# Altium schematic sheet MCU.SchDoc(MCU)
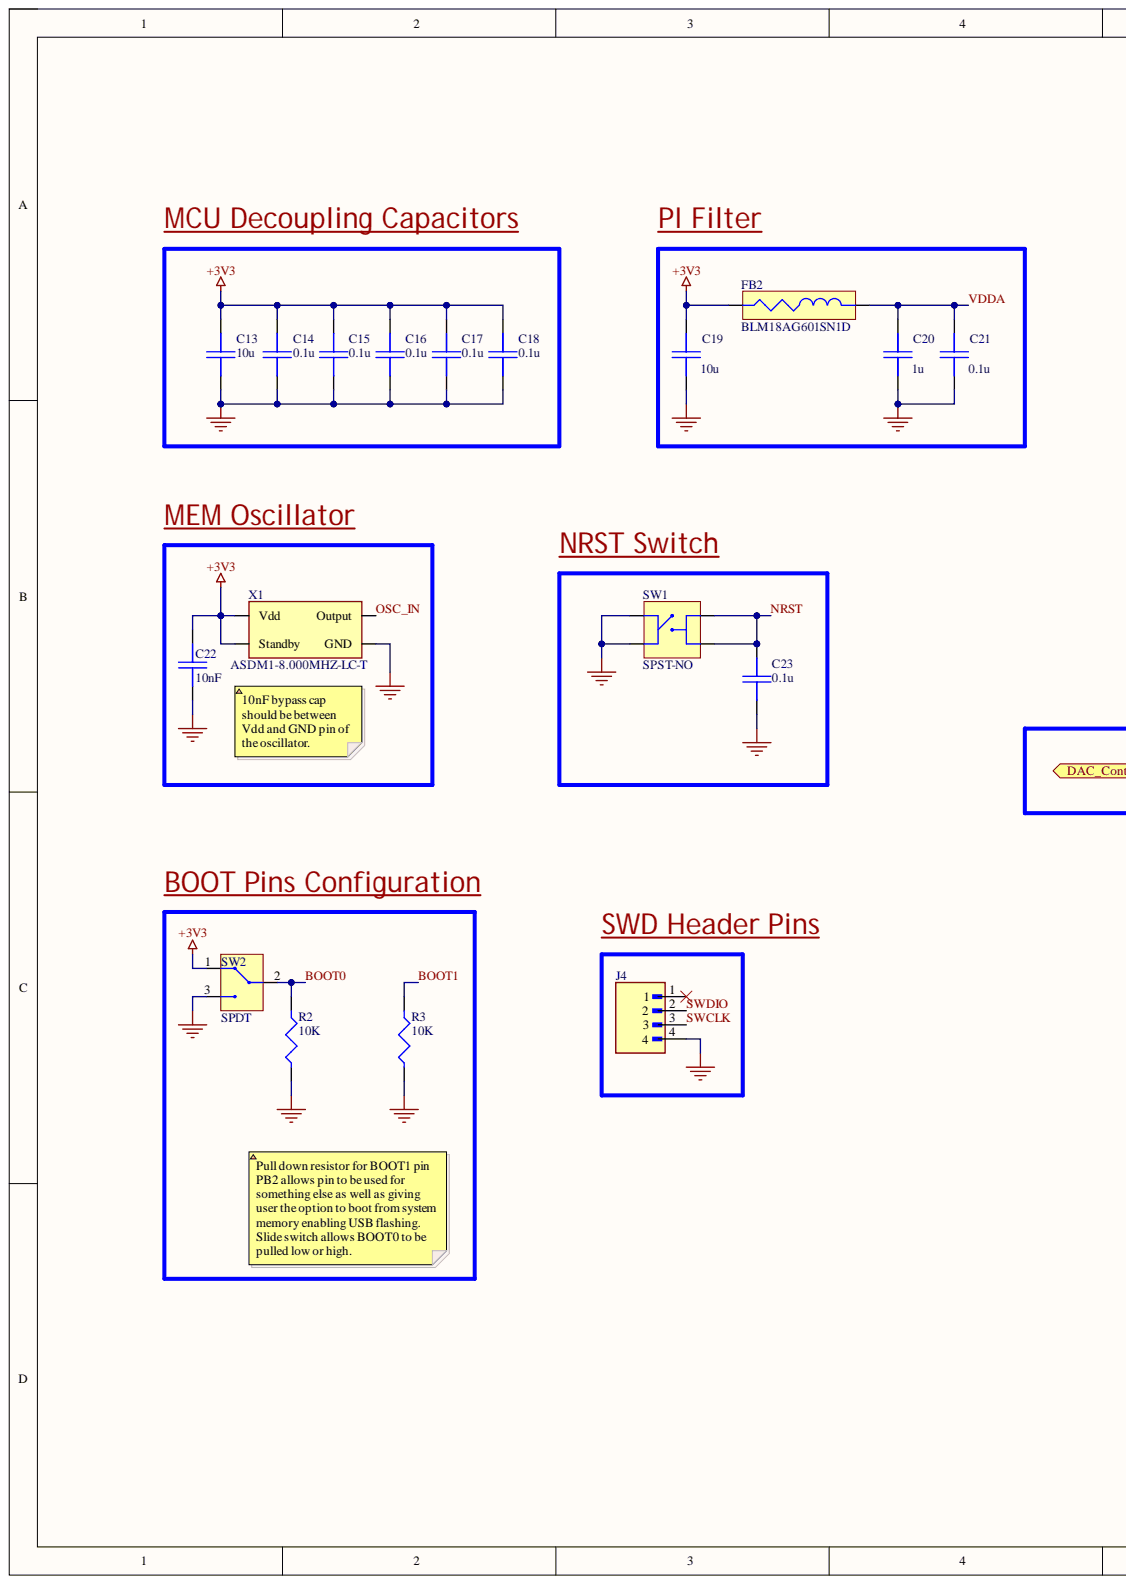
[diagram p0: MCU.SchDoc, left]
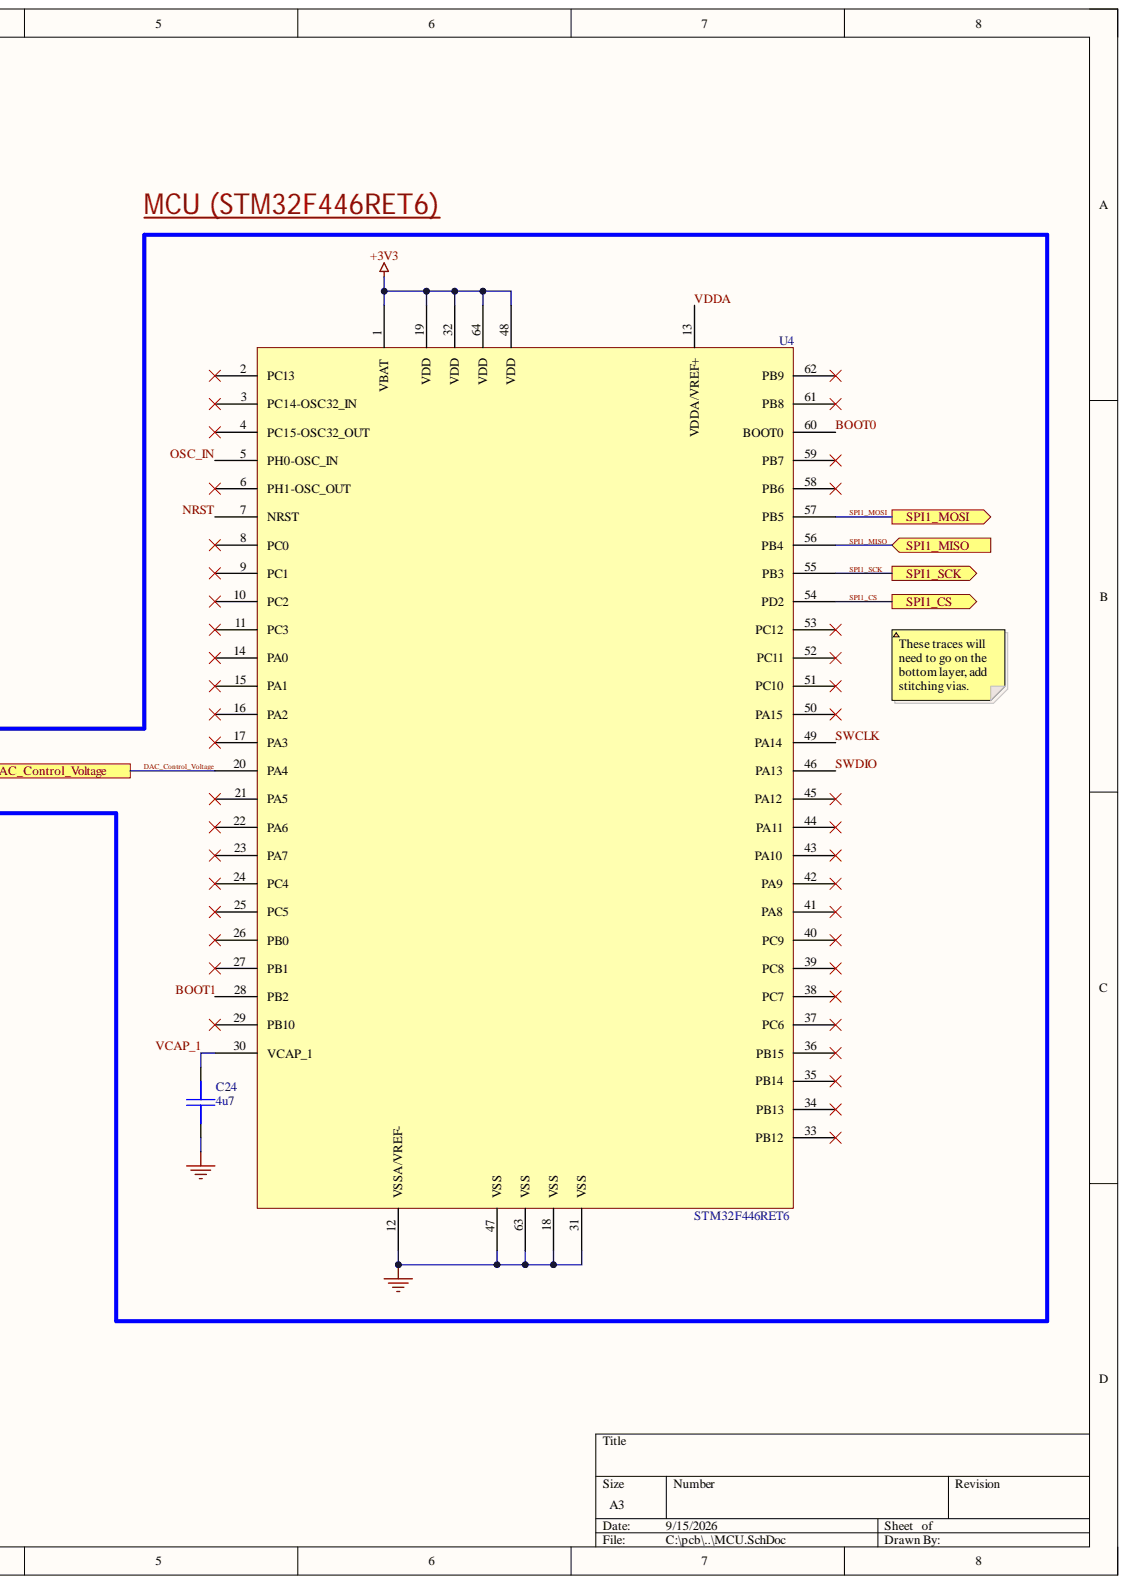
[diagram p1: MCU.SchDoc, right]

## Parts
C13  10u  [C0805]
C14  0.1u  [C0402]
C15  0.1u  [C0402]
C16  0.1u  [C0402]
C17  0.1u  [C0402]
C18  0.1u  [C0402]
C19  10u  [C0805]
C20  1u  [C0603]
C21  0.1u  [C0402]
C22  10nF  [C0402]
C23  0.1u  [C0402]
C24  4u7  [C0603]
FB2  BLM18AG601SN1D  [R0603]
J4  G800W304018EU  [G800W304018EU]  (value not drawn)
R2  10K  [R0603]
R3  10K  [R0603]
SW1  SPST-NO  [CT11024.3F260]
SW2  SPDT  [OS102011MS2QN1]
U4  STM32F446RET6  [STM32F446RET6]
X1  ASDM1-8.000MHZ-LC-T  [ASDM1-8.000MHZ-LC-T]

## Ports
DAC_Control_Voltage, SPI1_CS, SPI1_MISO, SPI1_MOSI, SPI1_SCK

## Nets
+3V3: C13.2 C14.2 C15.2 C16.2 C17.2 C18.2 C19.2 C22.2 FB2.1 SW2.1 U4.1(VBAT) U4.19(VDD) U4.32(VDD) U4.48(VDD) U4.64(VDD) X1.1(Standby) X1.4(Vdd)
GND: C13.1 C14.1 C15.1 C16.1 C17.1 C18.1 C19.1 C20.1 C21.1 C22.1 C23.1 C24.1 J4.4 R2.1 R3.1 SW1.1 SW1.2 SW2.3 U4.12(VSSA/VREF-) U4.18(VSS) U4.31(VSS) U4.47(VSS) U4.63(VSS) X1.2(GND)
BOOT0: R2.2 SW2.2 U4.60(BOOT0)
BOOT1: R3.2 U4.28(PB2)
DAC_Control_Voltage: U4.20(PA4) ; port DAC_Control_Voltage
NRST: C23.2 SW1.3 SW1.4 U4.7(NRST)
OSC_IN: U4.5(PH0-OSC_IN) X1.3(Output)
SPI1_CS: U4.54(PD2) ; port SPI1_CS
SPI1_MISO: U4.56(PB4) ; port SPI1_MISO
SPI1_MOSI: U4.57(PB5) ; port SPI1_MOSI
SPI1_SCK: U4.55(PB3) ; port SPI1_SCK
SWCLK: J4.3 U4.49(PA14)
SWDIO: J4.2 U4.46(PA13)
VCAP_1: C24.2 U4.30(VCAP_1)
VDDA: C20.2 C21.2 FB2.2 U4.13(VDDA/VREF+)

Not connected: J4 1; U4 2(PC13) 3(PC14-OSC32_IN) 4(PC15-OSC32_OUT) 6(PH1-OSC_OUT) 8(PC0) 9(PC1) 10(PC2) 11(PC3) 14(PA0) 15(PA1) 16(PA2) 17(PA3) 21(PA5) 22(PA6) 23(PA7) 24(PC4) 25(PC5) 26(PB0) 27(PB1) 29(PB10) 33(PB12) 34(PB13) 35(PB14) 36(PB15) 37(PC6) 38(PC7) 39(PC8) 40(PC9) 41(PA8) 42(PA9) 43(PA10) 44(PA11) 45(PA12) 50(PA15) 51(PC10) 52(PC11) 53(PC12) 58(PB6) 59(PB7) 61(PB8) 62(PB9)
